# Altium schematic sheet Analog.SchDoc
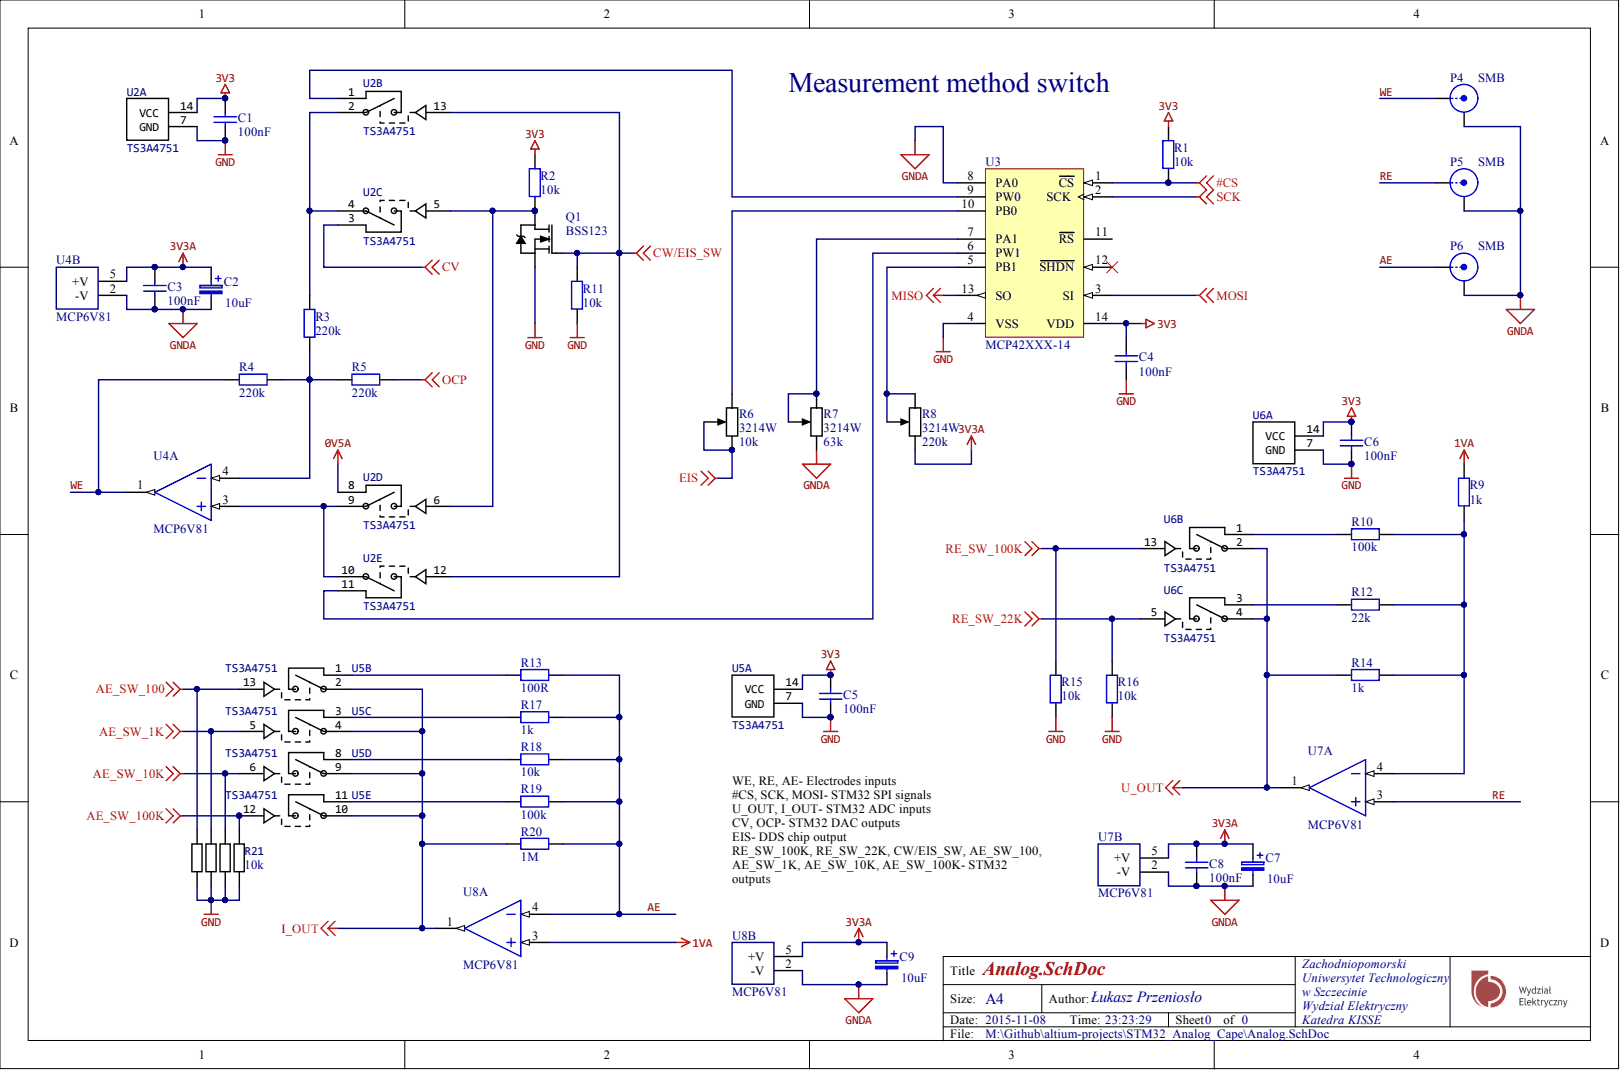
[diagram p0: Analog.SchDoc]

## Parts
C1  100nF  [CAPC1608N]
C2  10uF  [SMDA]
C3  100nF  [CAPC1608N]
C4  100nF  [CAPC1608N]
C5  100nF  [CAPC1608N]
C6  100nF  [CAPC1608N]
C7  10uF  [SMDA]
C8  100nF  [CAPC1608N]
C9  10uF  [SMDA]
P4  SMB  [SMB_STRAIGHT]
P5  SMB  [SMB_STRAIGHT]
P6  SMB  [SMB_STRAIGHT]
Q1  BSS123  [sot23-3l]
R1  10k  [RESC1608N]
R2  10k  [RESC1608N]
R3  220k  [RESC1608N]
R4  220k  [RESC1608N]
R5  220k  [RESC1608N]
R6  3214W  value 10k  [POT3214W]
R7  3214W  value 63k  [POT3214W]
R8  3214W  value 220k  [POT3214W]
R9  1k  [RESC1608N]
R10  100k  [RESC1608N]
R11  10k  [RESC1608N]
R12  22k  [RESC1608N]
R13  100R  [RESC1608N]
R14  1k  [RESC1608N]
R15  10k  [RESC1608N]
R16  10k  [RESC1608N]
R17  1k  [RESC1608N]
R18  10k  [RESC1608N]
R19  100k  [RESC1608N]
R20  1M  [RESC1608N]
R21  value 10k  [RES_ARRAY_4x0603]  (hidden comment: RES_ARRAY_4)
U2  TS3A4751  [TSSOP14]  (parts A,B,C,D,E)
U3  MCP42XXX-14  [SOIC-14]
U4  MCP6V81  [sot23-5]  (parts A,B)
U5  TS3A4751  [TSSOP14]  (parts A,B,C,D,E)
U6  TS3A4751  [TSSOP14]  (parts A,B,C)
U7  MCP6V81  [sot23-5]  (parts A,B)
U8  MCP6V81  [sot23-5]  (parts A,B)

## Nets
0V5A: U2.8
1VA: R9.1 U8.3
3V3: C1.2 C4.2 C5.2 C6.2 R1.2 R2.2 U2.14(VCC) U3.14(VDD) U5.14(VCC) U6.14(VCC)
3V3A: C2.1 C3.2 C7.1 C8.2 C9.1 R8.3 U4.5(+V) U7.5(+V) U8.5(+V)
#CS: R1.1 U3.1(CS)
AE_SW_1K: R21.5 U5.5
AE_SW_10K: R21.3 U5.6
AE_SW_100: R21.7 U5.13
AE_SW_100K: R21.1 U5.12
CV: U2.3
CW/EIS_SW: Q1.1 R11.2 U2.12 U2.13
EIS: R6.2 R6.3
GND: C1.1 C4.1 C5.1 C6.1 Q1.2 R11.1 R15.1 R16.1 R21.2 R21.4 R21.6 R21.8 U2.7(GND) U3.4(VSS) U5.7(GND) U6.7(GND)
GNDA: C2.2 C3.1 C7.2 C8.1 C9.2 P4.2 P5.2 P6.2 R7.3 U3.8(PA0) U4.2(-V) U7.2(-V) U8.2(-V)
I_OUT: R20.1 U5.2 U5.4 U5.9 U5.10 U8.1
MISO: U3.13(SO)
MOSI: U3.3(SI)
OCP: R5.1
RE_SW_22K: R16.2 U6.5
RE_SW_100K: R15.2 U6.13
SCK: U3.2(SCK)
U_OUT: R14.2 U6.2 U6.4 U7.1
AE: P6.1 R13.2 R17.1 R18.2 R19.2 R20.2 U8.4
RE: P5.1 U7.3
WE: P4.1 R4.2 U4.1
NetQ1_3: Q1.3 R2.1 U2.5 U2.6
NetR3_1: R3.1 R4.1 R5.2 U4.4
NetR3_2: R3.2 U2.2 U2.4
NetR6_1: R6.1 U3.10(PB0)
NetR7_1: R7.1 R7.2 U3.7(PA1)
NetR8_1: R8.1 R8.2 U3.5(PB1)
NetR9_2: R9.2 R10.1 R12.1 R14.1 U7.4
NetR10_2: R10.2 U6.1
NetR12_2: R12.2 U6.3
NetR13_1: R13.1 U5.1
NetR17_2: R17.2 U5.3
NetR18_1: R18.1 U5.8
NetR19_1: R19.1 U5.11
NetU2_1: U2.1 U3.9(PW0)
NetU2_9: U2.9 U2.10 U4.3
NetU2_11: U2.11 U3.6(PW1)

Not connected: U3 11(RS) 12(SHDN)

## Library data of the parts
C1 C3-C6 C8 = Capacitor: Component Kind: Standard; Description: Capacitor; Library Name: Discrete.SchLib; Signal Integrity: Cap; Simulation: CAP; Value: 100nF
C2 C7 C9 = 100uF 6.3V-SMDB: Polarized Capacitor (Surface Mount); Component Kind: Standard; Distributor: tme.pl; Distributor Order Code: TC 100/6.3-SMDB; farnell: 1135048; PackageReference: SMDB; Signal Integrity: Cap Pol; Simulation: CAP; tme.pl: TC 100/6.3-SMDB; Value: 10uF; Library Name: Mechatronic.SchLib
P4-P6 = SMB: SMB Straight Connector; Component Kind: Standard; LatestRevisionDate: 17-Jul-2002; LatestRevisionNote: Re-released for DXP Platform.; Library Name: Miscellaneous Connectors.IntLib; Signal Integrity: Connector; Publisher: Altium Limited
R1-R5 R9-R20 = Resistor: Power: 0W; Tolerance: 5%; Component Kind: Standard; Description: Resistor; Library Name: Discrete.SchLib; Signal Integrity: Res; Simulation: RESISTOR
  R1 R2 R11 R15 R16 R18: Value: 10k
  R3-R5: Value: 220k
  R9 R14 R17: Value: 1k
  R10 R19: Value: 100k
  R12: Value: 22k
  R13: Value: 100R
  R20: Value: 1M
R6-R8 = RPot: Potentiometer; Set Position: 0.5; Sim Note: Enter the Resistance value and the set point into parameter fields.; Component Kind: Standard; LatestRevisionDate: 17-Jul-2002; LatestRevisionNote: Re-released for DXP Platform.; PackageDescription: Variable Resistor, Thru-Hole; 3 Leads; PackageReference: VR5; Published: 8-Jun-2000; Simulation: POT; Library Name: my_various_devices.SchLib; Publisher: Altium Limited
R21 = RES_ARRAY_1206: Component Kind: Standard; Library Name: my_various_devices.SchLib
U2 U5 U6 = TS3A4751: TS3A4751 analog switch; Component Kind: Standard; Library Name: my_various_devices.SchLib
U3 = MCP42XXX-14: Single/Dual Digital Potentiometer with SPI Interface, 14-Pin SOIC 150mil, Industrial Temperature, Tape and Reel; Code_IPC: SOIC127P600-14; Code_JEDEC: MS-012-AB; Component Kind: Standard; ComponentLink1Description: Manufacturer URL; ComponentLink1URL: http://www.microchip.com/; ComponentLink2Description: Datasheet; ComponentLink2URL: http://ww1.microchip.com/downloads/en/DeviceDoc/11195c.pdf; ComponentLink3Description: Package Specification; ComponentLink3URL: http://www.microchip.com/stellent/groups/techpub_sg/documents/packagingspec/en012702.pdf; DatasheetVersion: Jul-2003; PackageDescription: 14-Lead Plastic Small Outline (SL) - Narrow, 3.90mm Body [SOIC]; PackageReference: SOIC-SL14; PackageVersion: revBB, Aug-2009; RevisionNote: URLs added.; Library Name: my_various_devices.SchLib
U4 U7 U8 = MCP6V81: Generic Part Number: AD8605; Manufacturer: Analog Devices; PartNumber: AD8605ART-REEL; Amplifiers Per Package: 1; Input Bias Current: 200fA; Input Offset Voltage: 20uV; Iq/Amp: 1.2mA; Slew Rate: 5V/us; Small Signal Bandwidth: 10MHz; V Span Max: 6V; V Span Min: 2.7V; Code_JEDEC: MO-178-AA; Component Kind: Standard; ComponentLink1Description: Manufacturer URL; ComponentLink1URL: http://www.analog.com/en/index.html; ComponentLink2Description: Datasheet; ComponentLink2URL: http://www.analog.com/static/imported-files/data_sheets/AD8605_8606_8608.pdf; ComponentLink3Description: Package Specification; ComponentLink3URL: http://www.analog.com/static/imported-files/packages/PKG_PDF/SOT-23(RJ)/RJ_5.pdf; ComponentLink4Description: Reference Circuit; ComponentLink4URL: http://www.analog.com/en/all-operational-amplifiers-op-amps/operational-amplifiers-op-amps/ad8605/products/product.html#product-recommendations; DatasheetVersion: Rev. L; Description: MCP6V81; Mounting Technology: Surface Mount; PackageDescription: 5-Pin Small Outline Transistor Package, Body 2.9 x 1.6 mm, Pitch 0.95 mm; PackageReference: RJ-5; PackageVersion: 121608-A; RevisionNote: Reference Circuit URL fixed; Library Name: my_various_devices.SchLib
Q1 = BSS123: Component Kind: Standard; Library Name: my_various_devices.SchLib
